# Altium schematic sheet Balance_car.SchDoc(Balance_car)
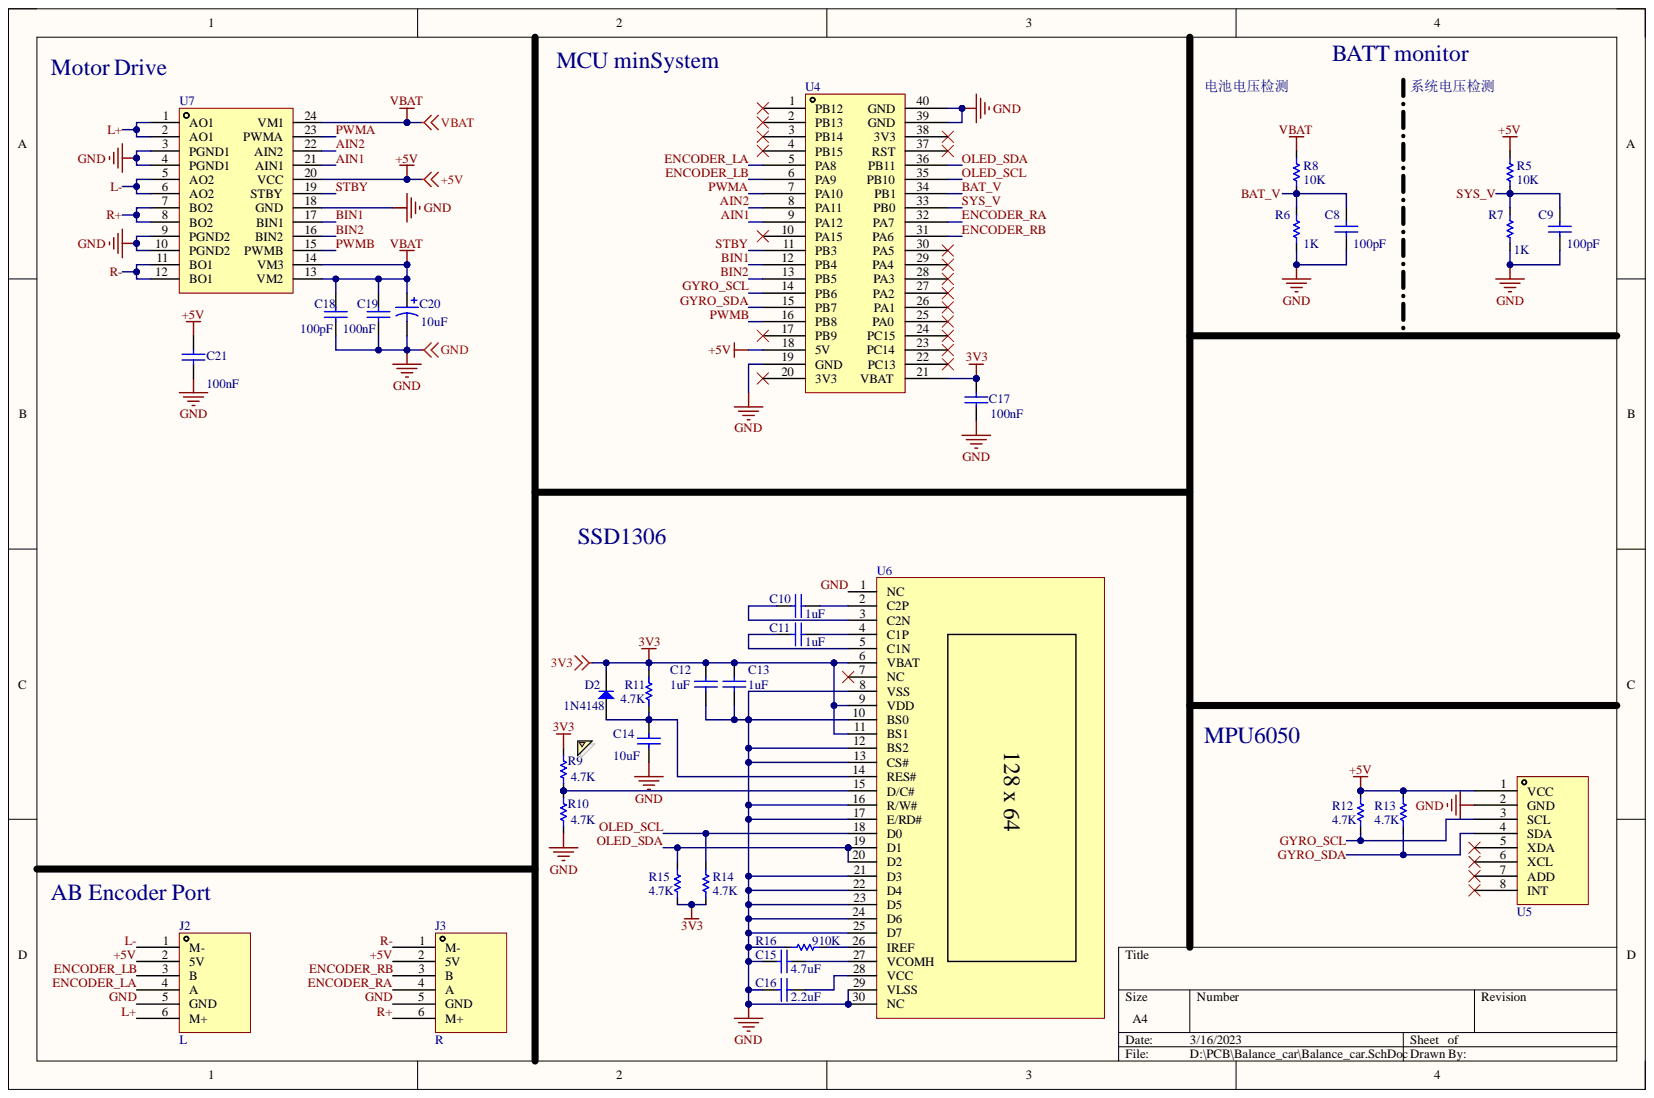
[diagram p0: Balance_car.SchDoc]

## Parts
C8  value 100pF  [C0603]  (hidden comment: Cap)
C9  value 100pF  [C0603]  (hidden comment: Cap)
C10  value 1uF  [C0603]  (hidden comment: Cap)
C11  value 1uF  [C0603]  (hidden comment: Cap)
C12  value 1uF  [C0603]  (hidden comment: Cap)
C13  value 1uF  [C0603]  (hidden comment: Cap)
C14  value 10uF  [C0603]  (hidden comment: Cap)
C15  value 4.7uF  [C0603]  (hidden comment: Cap)
C16  value 2.2uF  [C0603]  (hidden comment: Cap)
C17  value 100nF  [C0603]  (hidden comment: Cap)
C18  value 100pF  [C0603]  (hidden comment: Cap)
C19  value 100nF  [C0603]  (hidden comment: Cap)
C20  value 10uF  [CAP-SMD_L3.5-W2.8-R-RD]  (hidden comment: Cap Pol)
C21  value 100nF  [C0603]  (hidden comment: Cap)
D2  1N4148  [SOD-523F]
J2  L  [AB_Encoder]
J3  R  [AB_Encoder]
R5  value 10K  [R0603]  (hidden comment: Res)
R6  value 1K  [R0603]  (hidden comment: Res)
R7  value 1K  [R0603]  (hidden comment: Res)
R8  value 10K  [R0603]  (hidden comment: Res)
R9  value 4.7K  [R0603]  (hidden comment: Res)
R10  value 4.7K  [R0603]  (hidden comment: Res)
R11  value 4.7K  [R0603]  (hidden comment: Res)
R12  4.7K  [R0603]
R13  4.7K  [R0603]
R14  value 4.7K  [R0603]  (hidden comment: Res)
R15  value 4.7K  [R0603]  (hidden comment: Res)
R16  value 910K  [R0603]  (hidden comment: Res)
U4  STM32F103_mini  [STM32F103_mini]  (value not drawn)
U5  MPU6050  [MPU6050]  (value not drawn)
U6  VG-2864KSWEG01  [SSD1306]  (value not drawn)
U7  TB6612FNG  [SSOP-24_L8.3-W5.6-P0.65-LS7.6-BL]  (value not drawn)

## Nets
3V3: C12.1 C13.1 C17.2 D2.1 R9.1 R11.1 R14.2 R15.2 U4.21(VBAT) U6.6(VBAT) U6.9(VDD) U6.11(BS1)
VBAT: C18.2 C19.2 C20.1 R8.1 U7.13(VM2) U7.14(VM3) U7.24(VM1)
+5V: C21.2 J2.2(5V) J3.2(5V) R5.1 R12.2 R13.2 U4.18(5V) U5.1(VCC) U7.20(VCC)
AIN1: U4.9(PA12) U7.21(AIN1)
AIN2: U4.8(PA11) U7.22(AIN2)
BAT_V: C8.1 R6.1 R8.2 U4.34(PB1)
BIN1: U4.12(PB4) U7.17(BIN1)
BIN2: U4.13(PB5) U7.16(BIN2)
ENCODER_LA: J2.4(A) U4.5(PA8)
ENCODER_LB: J2.3(B) U4.6(PA9)
ENCODER_RA: J3.4(A) U4.32(PA7)
ENCODER_RB: J3.3(B) U4.31(PA6)
GND: C8.2 C9.2 C12.2 C13.2 C14.2 C15.2 C16.2 C17.1 C18.1 C19.1 C20.2 C21.1 J2.5(GND) J3.5(GND) R6.2 R7.2 R10.2 R16.1 U4.19(GND) U4.39(GND) U4.40(GND) U5.2(GND) U6.1(NC) U6.8(VSS) U6.10(BS0) U6.12(BS2) U6.13(CS#) U6.16(R/W#) U6.17(E/RD#) U6.21(D3) U6.22(D4) U6.23(D5) U6.24(D6) U6.25(D7) U6.29(VLSS) U6.30(NC) U7.3(PGND1) U7.4(PGND1) U7.9(PGND2) U7.10(PGND2) U7.18(GND)
GYRO_SCL: R12.1 U4.14(PB6) U5.3(SCL)
GYRO_SDA: R13.1 U4.15(PB7) U5.4(SDA)
L+: J2.6(M+) U7.1(AO1) U7.2(AO1)
L-: J2.1(M-) U7.5(AO2) U7.6(AO2)
OLED_SCL: R14.1 U4.35(PB10) U6.18(D0)
OLED_SDA: R15.1 U4.36(PB11) U6.19(D1) U6.20(D2)
PWMA: U4.7(PA10) U7.23(PWMA)
PWMB: U4.16(PB8) U7.15(PWMB)
R+: J3.6(M+) U7.7(BO2) U7.8(BO2)
R-: J3.1(M-) U7.11(BO1) U7.12(BO1)
STBY: U4.11(PB3) U7.19(STBY)
SYS_V: C9.1 R5.2 R7.1 U4.33(PB0)
NetC10_1: C10.1 U6.2(C2P)
NetC10_2: C10.2 U6.3(C2N)
NetC11_1: C11.1 U6.4(C1P)
NetC11_2: C11.2 U6.5(C1N)
NetC14_1: C14.1 D2.2 R11.2 U6.14(RES#)
NetC15_1: C15.1 U6.27(VCOMH)
NetC16_1: C16.1 U6.28(VCC)
NetR9_2: R9.2 R10.1 U6.15(D/C#)
NetR16_2: R16.2 U6.26(IREF)

Not connected: U4 1(PB12) 2(PB13) 3(PB14) 4(PB15) 10(PA15) 17(PB9) 20(3V3) 22(PC13) 23(PC14) 24(PC15) 25(PA0) 26(PA1) 27(PA2) 28(PA3) 29(PA4) 30(PA5) 37(RST) 38(3V3); U5 5(XDA) 6(XCL) 7(ADD) 8(INT); U6 7(NC)

## Library data of the parts
C8-C19 C21 = Cap: Capacitor; Component Kind: Standard; LatestRevisionDate: 17-Jul-2002; LatestRevisionNote: Re-released for DXP Platform.; PackageDescription: Radial Cap, Thru-Hole; 2 Leads; 0.3 in Pin Spacing; PackageReference: RAD-0.3; Published: 8-Jun-2000; Signal Integrity: Cap; Simulation: CAP; Library Name: Component footprints.IntLib; Publisher: Altium Limited
C20 = Cap Pol: Polarized Capacitor (Axial); Component Kind: Standard; LatestRevisionDate: 17-Jul-2002; LatestRevisionNote: Re-released for DXP Platform.; PackageDescription: Polarized Capacitor; 2 Leads; PackageReference: POLAR0.8; Published: 8-Jun-2000; Signal Integrity: Cap Pol; Simulation: CAP; Library Name: Component footprints.IntLib; Publisher: Altium Limited
D2 = Diode: High Conductance Fast Diode; Code_JEDEC: DO-204-AH; Component Kind: Standard; ComponentLink1Description: Manufacturer Link; ComponentLink1URL: http://www.fairchildsemi.com/; ComponentLink2Description: Datasheet; ComponentLink2URL: http://www.fairchildsemi.com/ds/1N%2F1N4148.pdf; DatasheetVersion: 1997; LatestRevisionDate: 17-Jul-2002; LatestRevisionNote: Re-released for DXP Platform.; PackageDescription: Diode, Axial; Body 3.8 x 1.9 mm (LxDia. max), Lead Dia. 0.53 mm (max); PackageReference: DO-35; Published: 8-Jun-2000; Library Name: Component footprints.IntLib; Publisher: Altium Limited
J2 J3 = AB_Encoder: 带编码器 TT马达; Component Kind: Standard; Library Name: Common_Modules.IntLib
R5-R16 = Res: Resistor; Component Kind: Standard; LatestRevisionDate: 17-Jul-2002; LatestRevisionNote: Re-released for DXP Platform.; PackageDescription: Axial Device, Thru-Hole; 2 Leads; 0.3 in Pin Spacing; PackageReference: AXIAL-0.3; Published: 8-Jun-2000; Signal Integrity: Res; Simulation: RESISTOR; Library Name: Component footprints.IntLib; Publisher: Altium Limited
  R12 R13: Value: 4.7K
U4 = STM32F103_mini: STM32F1最小系统板; Component Kind: Standard; Library Name: Common_Modules.IntLib
U5 = MPU6050: GY-521; Component Kind: Standard; Library Name: Common_Modules.IntLib
U6 = SSD1306: Manufacturer: WiseChip(智晶光电); Add into BOM: yes; Component Kind: Standard; Convert to PCB: yes; Datasheet: https://atta.szlcsc.com/upload/public/pdf/source/20190118/C194313_EB7CEF44C1C1CB8B071218A368D72B17.pdf; Device: VG-2864KSWEG01; JLCPCB Part Class: Extended Part; LCSC Part Name: 0.96”128x64白色 VG-2864KSWEG01; Library Name: Common_Modules.IntLib; Main Control Chip: SSD1306; Manufacturer Part: VG-2864KSWEG01; Name: VG-2864KSWEG01; PCB3D: OLED_L26.7-W15.5-H1.4-0.96-30P-P0.70-12864; Size: 0.96; Supplier: LCSC; Supplier Footprint: -; Supplier Part: C194313; Symbol: VG-2864KSWEG01
U7 = TB6612FNG: Driver IC for Dual DC motor  SSOP24-P-300-0.65A; Component Kind: Standard; Library Name: Integrated_Library1.IntLib
